# Altium schematic sheet Pressure-Sensor.SchDoc(U_Pressure-Sensor)
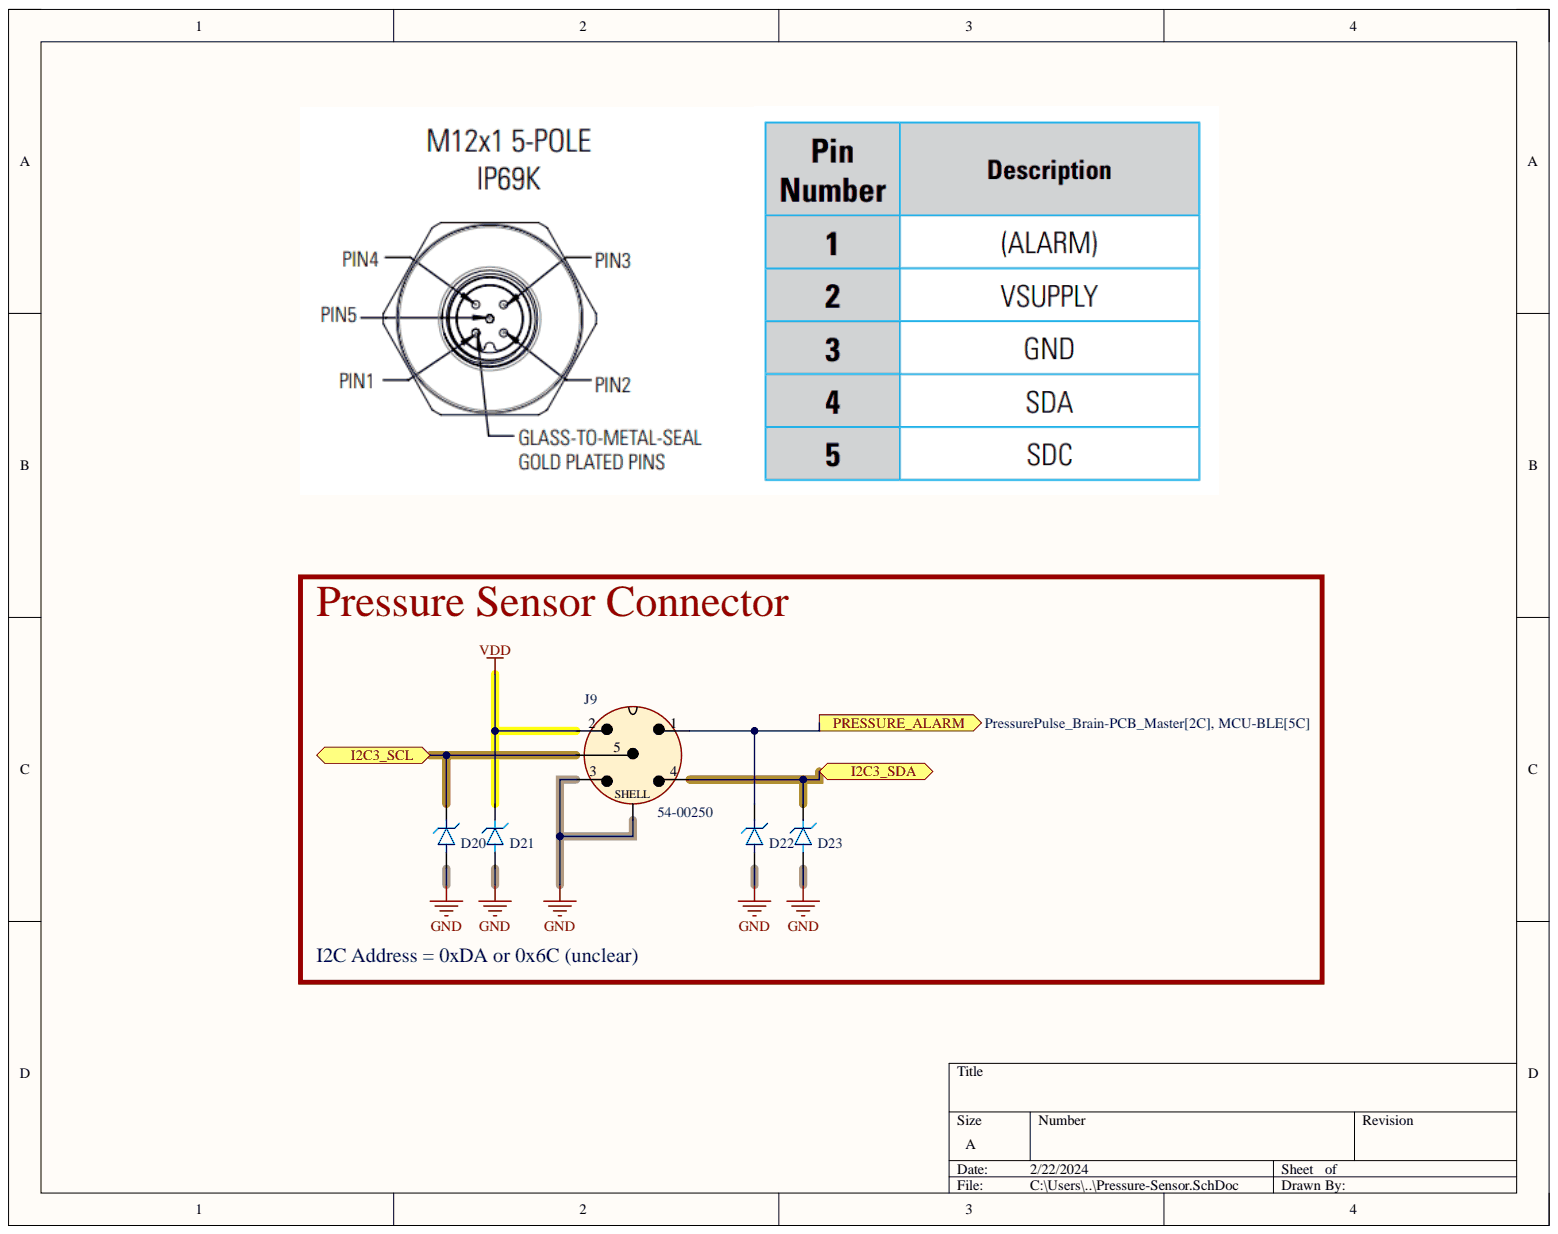
[diagram p0: Pressure-Sensor.SchDoc]

## Parts
D20  RCLAMP0521P.TCT  [RCLAMP0521P.TCT]  (value not drawn)
D21  RCLAMP0521P.TCT  [RCLAMP0521P.TCT]  (value not drawn)
D22  RCLAMP0521P.TCT  [RCLAMP0521P.TCT]  (value not drawn)
D23  RCLAMP0521P.TCT  [RCLAMP0521P.TCT]  (value not drawn)
J9  54-00250  [TENSILITY_54-00250]

## Ports
I2C3_SCL, I2C3_SDA, PRESSURE_ALARM

## Nets
GND: D20.A D21.A D22.A D23.A J9.3 J9.SHELL
VDD: D21.K J9.2
I2C3_SCL: D20.K J9.5 ; port I2C3_SCL
I2C3_SDA: D23.K J9.4 ; port I2C3_SDA
PRESSURE_ALARM: D22.K J9.1 ; port PRESSURE_ALARM

## Library data of the parts
D20-D23 = TVS DIODE: 25V Clamp 4A (8/20µs) Ipp Tvs Diode Surface Mount SLP1006P2; MFR: Semtech Corporation; Type: SMD; Component Kind: Standard; Library Name: PressurePulse.SchLib; MFR PN: RCLAMP0521P.TCT; Value: RCLAMP0521P.TCT
J9 = M12 5POS TH RA: CONN PLUG MALE 5POS GOLD SOLDER; MFR: Tensility International Corp; Value: DNS; Component Kind: Standard; Library Name: PressurePulse.SchLib; MFR PN: 54-00250
